# Altium schematic sheet Sheet2.SchDoc(Sheet2)
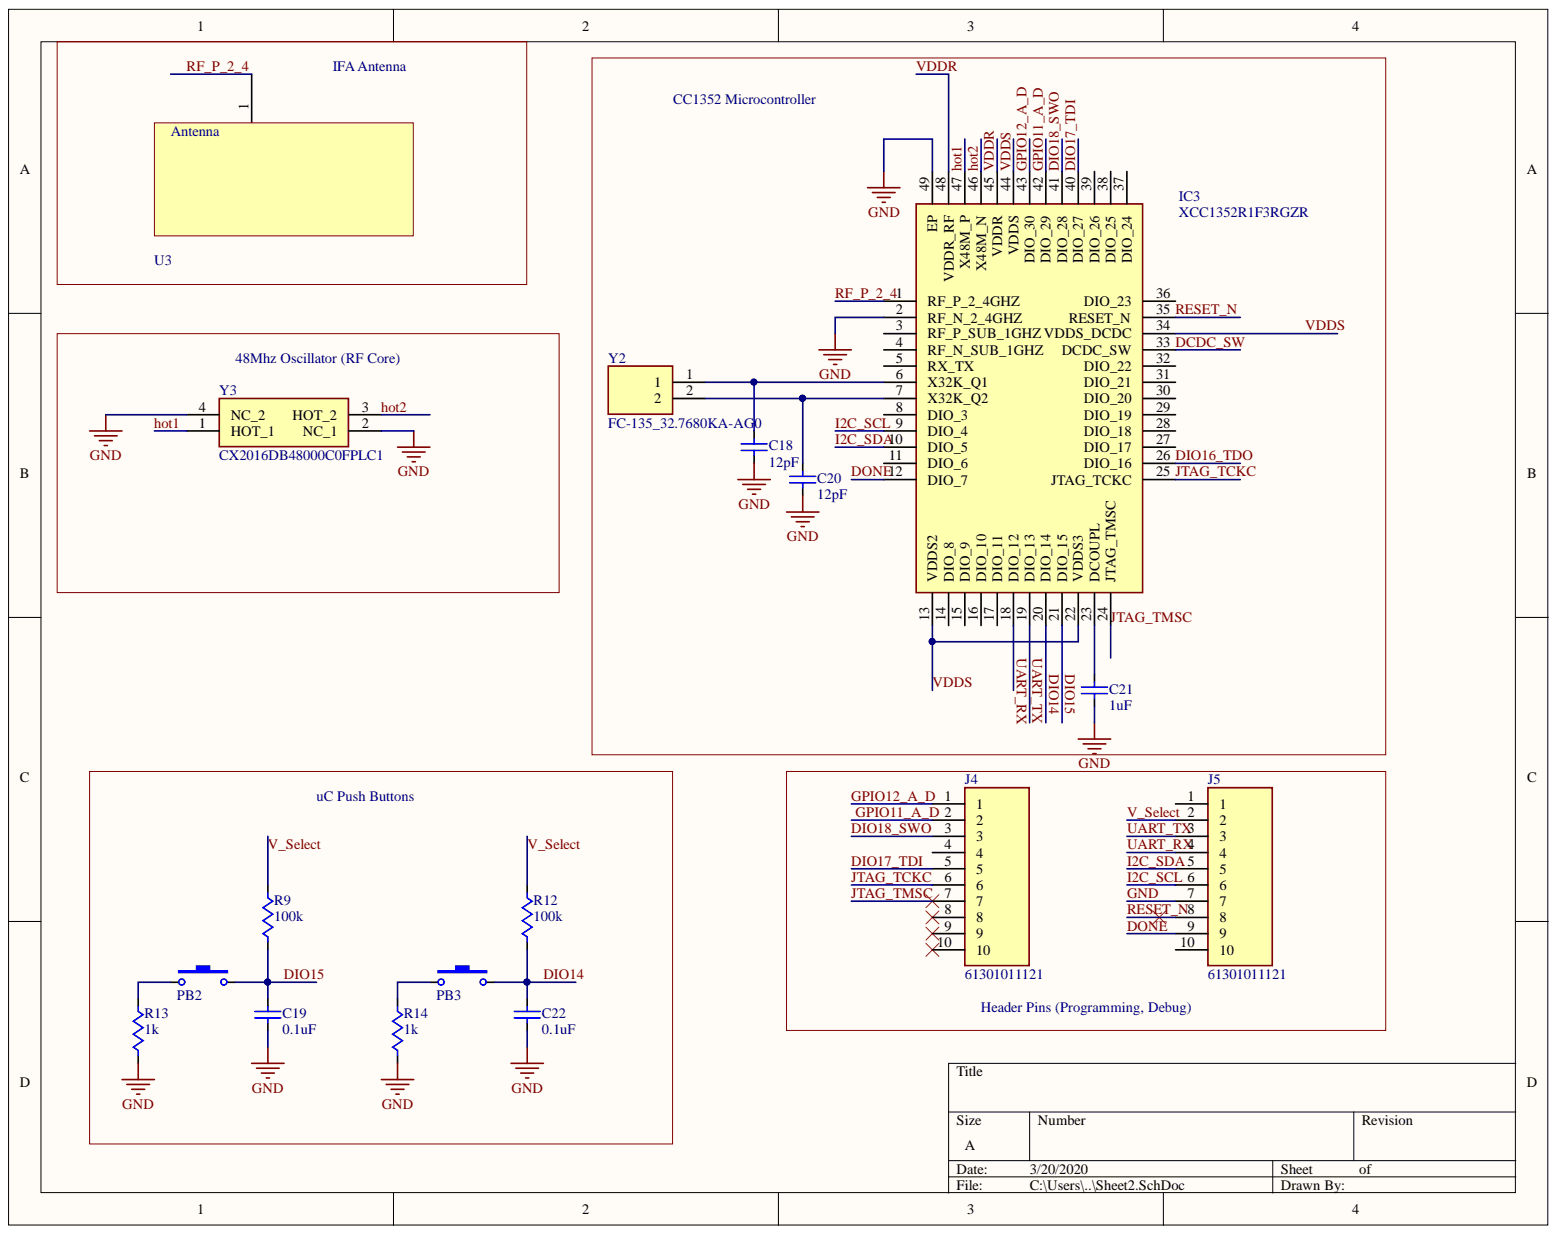
[diagram p0: Sheet2.SchDoc]

## Parts
C18  value 12pF  [PASSIVE-CAPACITOR-0603]  (hidden comment: Passive-Capacitor (Generic))
C19  value 0.1uF  [PASSIVE-CAPACITOR-0603]  (hidden comment: Passive-Capacitor (Generic))
C20  value 12pF  [PASSIVE-CAPACITOR-0603]  (hidden comment: Passive-Capacitor (Generic))
C21  value 1uF  [PASSIVE-CAPACITOR-0603]  (hidden comment: Passive-Capacitor (Generic))
C22  value 0.1uF  [PASSIVE-CAPACITOR-0603]  (hidden comment: Passive-Capacitor (Generic))
IC3  XCC1352R1F3RGZR  [QFN50P700X700X100-49N-D]
J4  61301011121  [HDRV10W64P0X254_1X10_2540X254X854P]
J5  61301011121  [HDRV10W64P0X254_1X10_2540X254X854P]
PB2  Interface-Push-Button  [INTERFACE-PUSH-BUTTON]  (value not drawn)
PB3  Interface-Push-Button  [INTERFACE-PUSH-BUTTON]  (value not drawn)
R9  value 100k  [PASSIVE-RESISTOR-0603]  (hidden comment: Passive-Resistor (Generic))
R12  value 100k  [PASSIVE-RESISTOR-0603]  (hidden comment: Passive-Resistor (Generic))
R13  value 1k  [PASSIVE-RESISTOR-0603]  (hidden comment: Passive-Resistor (Generic))
R14  value 1k  [PASSIVE-RESISTOR-0603]  (hidden comment: Passive-Resistor (Generic))
U3  RF_ANTENNA_2  [RF_TRACE_ANTENNA_2]  (value not drawn)
Y2  FC-135_32.7680KA-AG0  [FC135327680KAAG0]
Y3  CX2016DB48000C0FPLC1  [CX2016DB48000C0FPLC1]

## Nets
DCDC_SW: IC3.33(DCDC_SW)
DIO14: C22.1 IC3.20(DIO_14) PB3.2 R12.1
DIO15: C19.1 IC3.21(DIO_15) PB2.2 R9.1
DIO16_TDO: IC3.26(DIO_16)
DIO17_TDI: IC3.40(DIO_27) J4.5
DIO18_SWO: IC3.41(DIO_28) J4.3
DONE: IC3.12(DIO_7) J5.9
GND: C18.1 C19.2 C20.1 C21.1 C22.2 IC3.2(RF_N_2_4GHZ) IC3.49(EP) J5.7 R13.1 R14.1 Y3.2(NC_1) Y3.4(NC_2)
GPIO11_A_D: IC3.42(DIO_29) J4.2
GPIO12_A_D: IC3.43(DIO_30) J4.1
hot1: IC3.47(X48M_P) Y3.1(HOT_1)
hot2: IC3.46(X48M_N) Y3.3(HOT_2)
I2C_SCL: IC3.9(DIO_4) J5.6
I2C_SDA: IC3.10(DIO_5) J5.5
JTAG_TCKC: IC3.25(JTAG_TCKC) J4.6
JTAG_TMSC: IC3.24(JTAG_TMSC) J4.7
RESET_N: IC3.35(RESET_N) J5.8
RF_P_2_4: IC3.1(RF_P_2_4GHZ) U3.1
UART_RX: IC3.18(DIO_12) J5.4
UART_TX: IC3.19(DIO_13) J5.3
V_Select: J5.2 R9.2 R12.2
VDDR: IC3.45(VDDR) IC3.48(VDDR_RF)
VDDS: IC3.13(VDDS2) IC3.22(VDDS3) IC3.34(VDDS_DCDC) IC3.44(VDDS)
NetC18_2: C18.2 IC3.6(X32K_Q1) Y2.1
NetC20_2: C20.2 IC3.7(X32K_Q2) Y2.2
NetC21_2: C21.2 IC3.23(DCOUPL)
NetPB2_1: PB2.1 R13.2
NetPB3_1: PB3.1 R14.2

Not connected: IC3 3(RF_P_SUB_1GHZ) 4(RF_N_SUB_1GHZ) 5(RX_TX) 8(DIO_3) 11(DIO_6) 14(DIO_8) 15(DIO_9) 16(DIO_10) 17(DIO_11) 27(DIO_17) 28(DIO_18) 29(DIO_19) 30(DIO_20) 31(DIO_21) 32(DIO_22) 36(DIO_23) 37(DIO_24) 38(DIO_25) 39(DIO_26); J4 4 8 9 10; J5 1 10

## Library data of the parts
C18-C22 = Passive-Capacitor (Generic): Generic Capacitor; Supplier 2: Digi-Key; Supplier 1: Mouser; Library Name: MasterLib.IntLib; Component Kind: Standard; Category: Passive
IC3 = XCC1352R1F3RGZR: Integrated Circuit; Manufacturer_Name: Texas Instruments; Manufacturer_Part_Number: XCC1352R1F3RGZR; Height: 1mm; Datasheet Link: http://www.ti.com/lit/gpn/cc1352r; Component Kind: Standard
J4 J5 = 61301011121: Connector; Manufacturer_Name: Wurth Elektronik; RS Price/Stock: http://uk.rs-online.com/web/p/products/7636757P; RS Part Number: 7636757P; Mouser Price/Stock: https://www.mouser.com/Search/Refine.aspx?Keyword=710-61301011121; Mouser Part Number: 710-61301011121; Manufacturer_Part_Number: 61301011121; Height: 8.54mm; Datasheet Link: http://www.farnell.com/datasheets/1442175.pdf?_ga=1.151831673.1948394759.1473026436; Component Kind: Standard
PB2 PB3 = Interface-Push-Button: 2.5mm Tall, 2.5N Force, Straight, SMD, Push Button; Manufacturer Part Number: PTS820 J25K SMTR LFS; Manufacturer: C&K Components; Value: Single; Through Hole (y/n): N; Supplier Part Number 2: CKN10897CT-ND; Supplier Part Number 1: 611-PTS820J25KSMTR; Supplier 2: Digi-Key; Supplier 1: Mouser; Package: SMD-6; Library Name: MasterLib.IntLib; ComponentLink1URL: https://www.mouser.com/datasheet/2/60/pts820-1382642.pdf; ComponentLink1Description: Datasheet; Component Kind: Standard; Category: Interface
R9 R12-R14 = Passive-Resistor (Generic): Generic Resistor; Through Hole (y/n): N; Supplier 2: Digi-Key; Supplier 1: Mouser; Library Name: MasterLib.IntLib; Component Kind: Standard; Category: Passive
Y2 = FC-135_32.7680KA-AG0: Crystal or Oscillator; Manufacturer_Part_Number: FC-135 32.7680KA-AG0; Manufacturer_Name: Epson Timing; Mouser Price/Stock: https://www.mouser.com/Search/Refine.aspx?Keyword=732-FC135-32.76KAAG0; Mouser Part Number: 732-FC135-32.76KAAG0; Height: 0mm; Datasheet Link: https://support.epson.biz/td/api/doc_check.php?dl=brief_FC-135&lang=en/.pdf; Component Kind: Standard
Y3 = CX2016DB48000C0FPLC1: Crystal or Oscillator; Manufacturer_Name: Kyocera Electronic Components; Mouser Price/Stock: https://www.mouser.com/Search/Refine.aspx?Keyword=581-CX2016DB48CFPLC1; Mouser Part Number: 581-CX2016DB48CFPLC1; Manufacturer_Part_Number: CX2016DB48000C0FPLC1; Datasheet Link: https://componentsearchengine.com/Datasheets/1/CX2016DB48000C0FPLC1.pdf; Component Kind: Standard
U3 = RF_ANTENNA_2: Library Name: V3_Lib.IntLib; Component Kind: Standard
